# Altium schematic sheet 04 DragonBoard_INTF.SchDoc
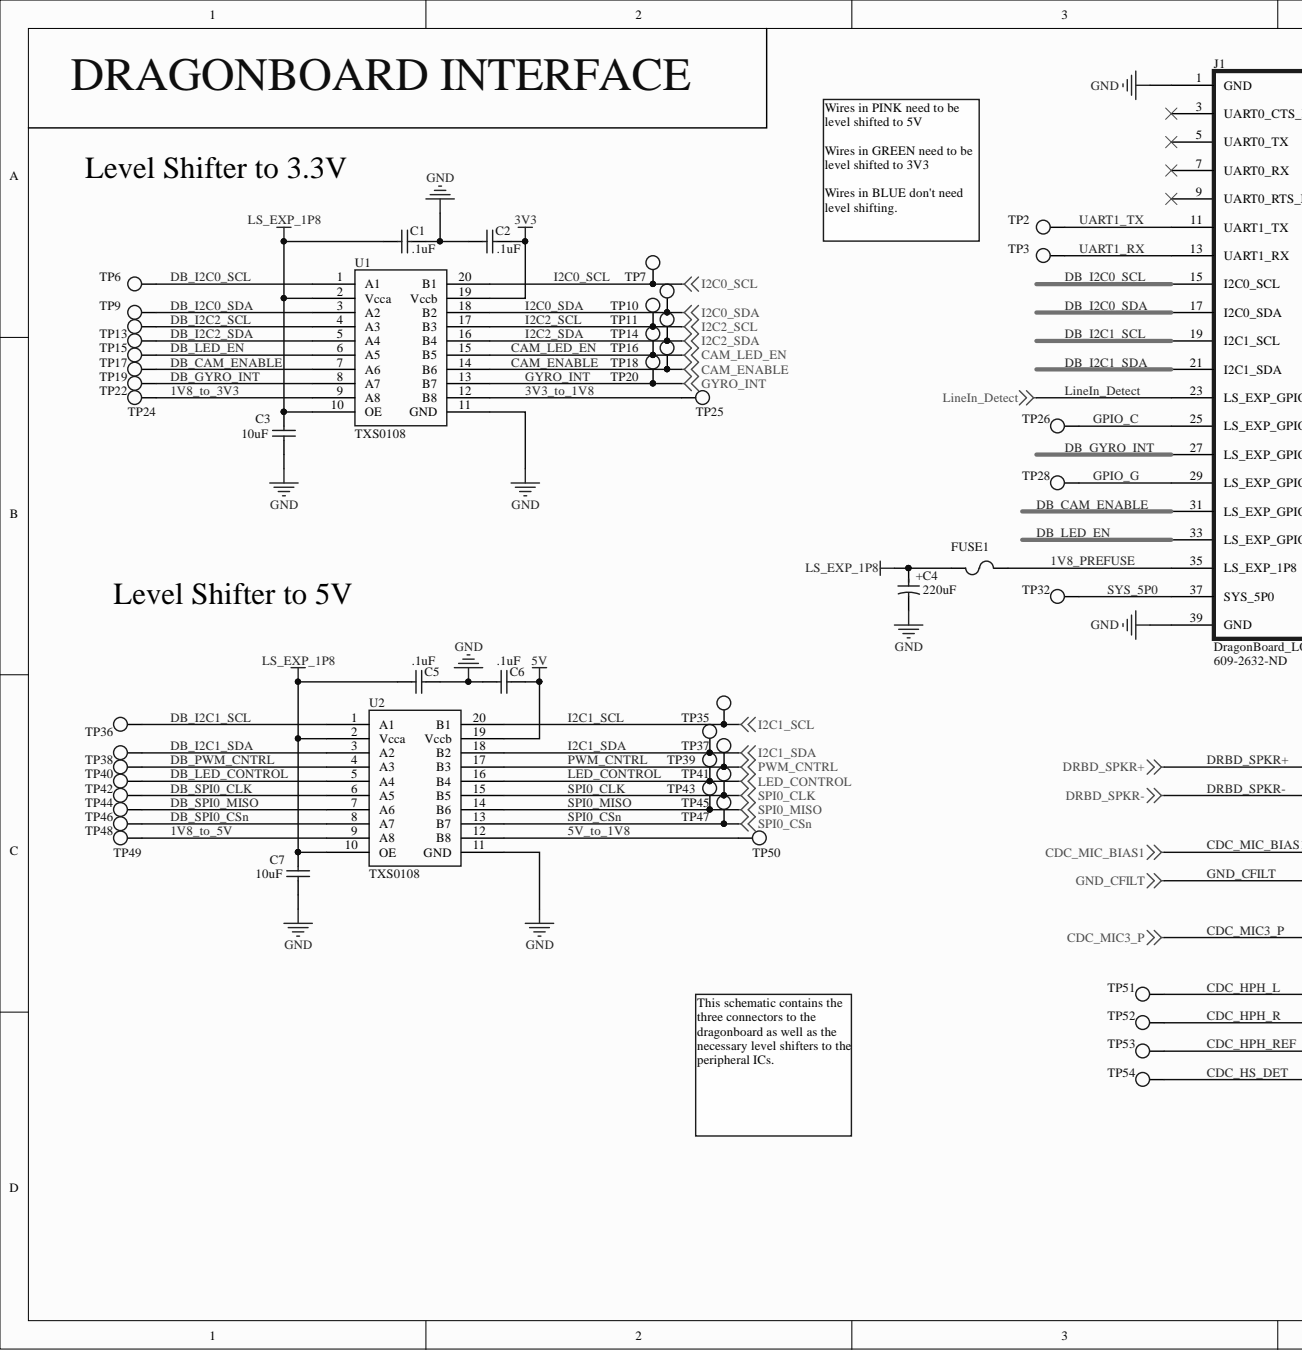
[diagram p0: 04 DragonBoard_INTF.SchDoc, left]
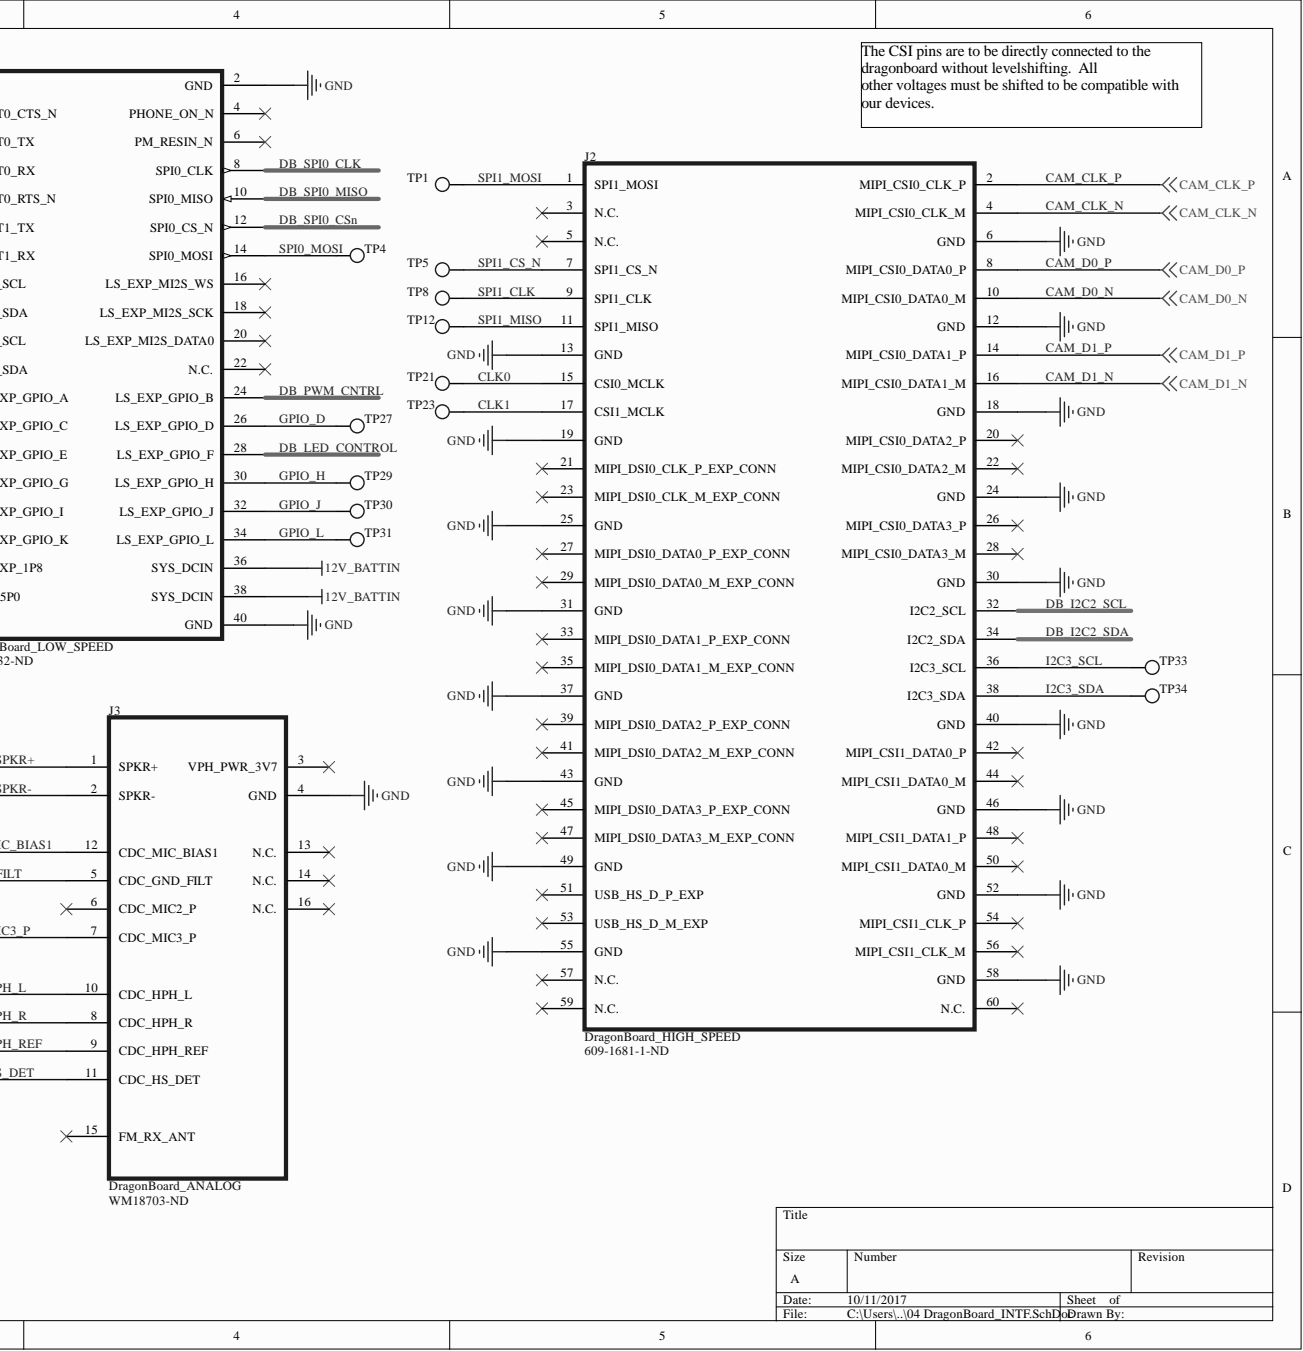
[diagram p1: 04 DragonBoard_INTF.SchDoc, right]

## Parts
C1  value .1uF  [CAPC2012X135N]  (hidden comment: CAP_0805_.1u_250V)
C2  value .1uF  [CAPC2012X135N]  (hidden comment: CAP_0805_.1u_250V)
C3  value 10uF  Capacitance: 10uF  [CAPC2012X135N]  (hidden comment: CAP_0805_10u_16V)
C4  Capacitance: 220uF  [CAP_RADIAL]  (hidden comment: CAP ALUM 220UF 20% 25V SMD)
C5  value .1uF  [CAPC2012X135N]  (hidden comment: CAP_0805_.1u_250V)
C6  value .1uF  [CAPC2012X135N]  (hidden comment: CAP_0805_.1u_250V)
C7  value 10uF  Capacitance: 10uF  [CAPC2012X135N]  (hidden comment: CAP_0805_10u_16V)
FUSE1  FUSE_0805_1A_32VDC  [RES0805]  (value not drawn)
J1  DragonBoard_LOW_SPEED  Supplier Part Number 1: 609-2632-ND  [57202_40_PIN_HEADER]
J2  DragonBoard_HIGH_SPEED  Supplier Part Number 1: 609-1681-1-ND  [DB_HIGH_SPEED]
J3  DragonBoard_ANALOG  Supplier Part Number 1: WM18703-ND  [16_PIN_ANALOG_CONN]
TP1  TP  [TestPoint_1206]
TP2  TP  [TestPoint_1206]
TP3  TP  [TestPoint_1206]
TP4  TP  [TestPoint_1206]
TP5  TP  [TestPoint_1206]
TP6  TP  [TestPoint_1206]
TP7  TP  [TestPoint_1206]
TP8  TP  [TestPoint_1206]
TP9  TP  [TestPoint_1206]
TP10  TP  [TestPoint_1206]
TP11  TP  [TestPoint_1206]
TP12  TP  [TestPoint_1206]
TP13  TP  [TestPoint_1206]
TP14  TP  [TestPoint_1206]
TP15  TP  [TestPoint_1206]
TP16  TP  [TestPoint_1206]
TP17  TP  [TestPoint_1206]
TP18  TP  [TestPoint_1206]
TP19  TP  [TestPoint_1206]
TP20  TP  [TestPoint_1206]
TP21  TP  [TestPoint_1206]
TP22  TP  [TestPoint_1206]
TP23  TP  [TestPoint_1206]
TP24  TP  [TestPoint_1206]
TP25  TP  [TestPoint_1206]
TP26  TP  [TestPoint_1206]
TP27  TP  [TestPoint_1206]
TP28  TP  [TestPoint_1206]
TP29  TP  [TestPoint_1206]
TP30  TP  [TestPoint_1206]
TP31  TP  [TestPoint_1206]
TP32  TP  [TestPoint_1206]
TP33  TP  [TestPoint_1206]
TP34  TP  [TestPoint_1206]
TP35  TP  [TestPoint_1206]
TP36  TP  [TestPoint_1206]
TP37  TP  [TestPoint_1206]
TP38  TP  [TestPoint_1206]
TP39  TP  [TestPoint_1206]
TP40  TP  [TestPoint_1206]
TP41  TP  [TestPoint_1206]
TP42  TP  [TestPoint_1206]
TP43  TP  [TestPoint_1206]
TP44  TP  [TestPoint_1206]
TP45  TP  [TestPoint_1206]
TP46  TP  [TestPoint_1206]
TP47  TP  [TestPoint_1206]
TP48  TP  [TestPoint_1206]
TP49  TP  [TestPoint_1206]
TP50  TP  [TestPoint_1206]
TP51  TP  [TestPoint_1206]
TP52  TP  [TestPoint_1206]
TP53  TP  [TestPoint_1206]
TP54  TP  [TestPoint_1206]
U1  TXS0108  [TSSOP20]
U2  TXS0108  [TSSOP20]

## Nets
3V3: C2.2 U1.19(Vccb)
5V: C6.2 U2.19(Vccb)
12V_BATTIN: J1.36(SYS_DCIN) J1.38(SYS_DCIN)
GND: C1.2 C2.1 C3.1 C4.2 C5.2 C6.1 C7.1 J1.1(GND) J1.2(GND) J1.39(GND) J1.40(GND) J2.6(GND) J2.12(GND) J2.13(GND) J2.18(GND) J2.19(GND) J2.24(GND) J2.25(GND) J2.30(GND) J2.31(GND) J2.37(GND) J2.40(GND) J2.43(GND) J2.46(GND) J2.49(GND) J2.52(GND) J2.55(GND) J2.58(GND) J3.4(GND) U1.11(GND) U2.11(GND)
LS_EXP_1P8: C1.1 C3.2 C4.1 C5.1 C7.2 FUSE1.1 U1.2(Vcca) U1.10(OE) U2.2(Vcca) U2.10(OE)
1V8_PREFUSE: FUSE1.2 J1.35(LS_EXP_1P8)
1V8_to_3V3: TP24.1 U1.9(A8)
1V8_to_5V: TP49.1 U2.9(A8)
3V3_to_1V8: TP25.1 U1.12(B8)
5V_to_1V8: TP50.1 U2.12(B8)
CAM_CLK_N: J2.4(MIPI_CSI0_CLK_M)
CAM_CLK_P: J2.2(MIPI_CSI0_CLK_P)
CAM_D0_N: J2.10(MIPI_CSI0_DATA0_M)
CAM_D0_P: J2.8(MIPI_CSI0_DATA0_P)
CAM_D1_N: J2.16(MIPI_CSI0_DATA1_M)
CAM_D1_P: J2.14(MIPI_CSI0_DATA1_P)
CAM_ENABLE: TP18.1 U1.14(B6)
CAM_LED_EN: TP16.1 U1.15(B5)
CDC_HPH_L: J3.10(CDC_HPH_L) TP51.1
CDC_HPH_R: J3.8(CDC_HPH_R) TP52.1
CDC_HPH_REF: J3.9(CDC_HPH_REF) TP53.1
CDC_HS_DET: J3.11(CDC_HS_DET) TP54.1
CDC_MIC3_P: J3.7(CDC_MIC3_P)
CDC_MIC_BIAS1: J3.12(CDC_MIC_BIAS1)
CLK0: J2.15(CSI0_MCLK) TP21.1
CLK1: J2.17(CSI1_MCLK) TP23.1
DB_CAM_ENABLE: J1.31(LS_EXP_GPIO_I) TP19.1 U1.7(A6)
DB_GYRO_INT: J1.27(LS_EXP_GPIO_E) TP22.1 U1.8(A7)
DB_I2C0_SCL: J1.15(I2C0_SCL) TP6.1 U1.1(A1)
DB_I2C0_SDA: J1.17(I2C0_SDA) TP9.1 U1.3(A2)
DB_I2C1_SCL: J1.19(I2C1_SCL) TP36.1 U2.1(A1)
DB_I2C1_SDA: J1.21(I2C1_SDA) TP38.1 U2.3(A2)
DB_I2C2_SCL: J2.32(I2C2_SCL) TP13.1 U1.4(A3)
DB_I2C2_SDA: J2.34(I2C2_SDA) TP15.1 U1.5(A4)
DB_LED_CONTROL: J1.28(LS_EXP_GPIO_F) TP42.1 U2.5(A4)
DB_LED_EN: J1.33(LS_EXP_GPIO_K) TP17.1 U1.6(A5)
DB_PWM_CNTRL: J1.24(LS_EXP_GPIO_B) TP40.1 U2.4(A3)
DB_SPI0_CLK: J1.8(SPI0_CLK) TP44.1 U2.6(A5)
DB_SPI0_CSn: J1.12(SPI0_CS_N) TP48.1 U2.8(A7)
DB_SPI0_MISO: J1.10(SPI0_MISO) TP46.1 U2.7(A6)
DRBD_SPKR+: J3.1(SPKR+)
DRBD_SPKR-: J3.2(SPKR-)
GND_CFILT: J3.5(CDC_GND_FILT)
GPIO_C: J1.25(LS_EXP_GPIO_C) TP26.1
GPIO_D: J1.26(LS_EXP_GPIO_D) TP27.1
GPIO_G: J1.29(LS_EXP_GPIO_G) TP28.1
GPIO_H: J1.30(LS_EXP_GPIO_H) TP29.1
GPIO_J: J1.32(LS_EXP_GPIO_J) TP30.1
GPIO_L: J1.34(LS_EXP_GPIO_L) TP31.1
GYRO_INT: TP20.1 U1.13(B7)
I2C0_SCL: TP7.1 U1.20(B1)
I2C0_SDA: TP10.1 U1.18(B2)
I2C1_SCL: TP35.1 U2.20(B1)
I2C1_SDA: TP37.1 U2.18(B2)
I2C2_SCL: TP11.1 U1.17(B3)
I2C2_SDA: TP14.1 U1.16(B4)
I2C3_SCL: J2.36(I2C3_SCL) TP33.1
I2C3_SDA: J2.38(I2C3_SDA) TP34.1
LED_CONTROL: TP41.1 U2.16(B4)
LineIn_Detect: J1.23(LS_EXP_GPIO_A)
PWM_CNTRL: TP39.1 U2.17(B3)
SPI0_CLK: TP43.1 U2.15(B5)
SPI0_CSn: TP47.1 U2.13(B7)
SPI0_MISO: TP45.1 U2.14(B6)
SPI0_MOSI: J1.14(SPI0_MOSI) TP4.1
SPI1_CLK: J2.9(SPI1_CLK) TP8.1
SPI1_CS_N: J2.7(SPI1_CS_N) TP5.1
SPI1_MISO: J2.11(SPI1_MISO) TP12.1
SPI1_MOSI: J2.1(SPI1_MOSI) TP1.1
SYS_5P0: J1.37(SYS_5P0) TP32.1
UART1_RX: J1.13(UART1_RX) TP3.1
UART1_TX: J1.11(UART1_TX) TP2.1

Not connected: J1 3(UART0_CTS_N) 4(PHONE_ON_N) 5(UART0_TX) 6(PM_RESIN_N) 7(UART0_RX) 9(UART0_RTS_N) 16(LS_EXP_MI2S_WS) 18(LS_EXP_MI2S_SCK) 20(LS_EXP_MI2S_DATA0) 22(N.C.); J2 3(N.C.) 5(N.C.) 20(MIPI_CSI0_DATA2_P) 21(MIPI_DSI0_CLK_P_EXP_CONN) 22(MIPI_CSI0_DATA2_M) 23(MIPI_DSI0_CLK_M_EXP_CONN) 26(MIPI_CSI0_DATA3_P) 27(MIPI_DSI0_DATA0_P_EXP_CONN) 28(MIPI_CSI0_DATA3_M) 29(MIPI_DSI0_DATA0_M_EXP_CONN) 33(MIPI_DSI0_DATA1_P_EXP_CONN) 35(MIPI_DSI0_DATA1_M_EXP_CONN) 39(MIPI_DSI0_DATA2_P_EXP_CONN) 41(MIPI_DSI0_DATA2_M_EXP_CONN) 42(MIPI_CSI1_DATA0_P) 44(MIPI_CSI1_DATA0_M) 45(MIPI_DSI0_DATA3_P_EXP_CONN) 47(MIPI_DSI0_DATA3_M_EXP_CONN) 48(MIPI_CSI1_DATA1_P) 50(MIPI_CSI1_DATA0_M) 51(USB_HS_D_P_EXP) 53(USB_HS_D_M_EXP) 54(MIPI_CSI1_CLK_P) 56(MIPI_CSI1_CLK_M) 57(N.C.) 59(N.C.) 60(N.C.); J3 3(VPH_PWR_3V7) 6(CDC_MIC2_P) 13(N.C.) 14(N.C.) 15(FM_RX_ANT) 16(N.C.)

## Library data of the parts
C1 C2 C5 C6 = CAP_0805_.1u_250V: Cap Ceramic 0.1uF 250V X7T 10% SMD 0805 125C Plastic T/R; Manufacturer: TDK; Manufacturer Part Number: C2012X7T2E104K125AE; Capacitance: 100nF; Case/Package: 0805; Case Code (Imperial): 0805; Case Code (Metric): 2012; Component Kind: Standard; ComponentLink1Description: https://datasheet.ciiva.com/24219/c2012x7t2e104k125ae-265154-24219519.pdf; ComponentLink1URL: https://datasheet.ciiva.com/24219/c2012x7t2e104k125ae-265154-24219519.pdf; Composition: Ceramic; Depth: 1.25mm; Dielectric: X7T; Height: 1.25mm; Length: 2mm; Max Operating Temperature: 125°C; Min Operating Temperature: -55°C; Package Quantity: 1; Packaging: Tape and Reel; Pricing 1: 1=0.37, 10=0.25, 100=0.1419, 500=0.1002, 1000=0.0877 (USD); REACH SVHC: No SVHC; Stock 1: 30376; Supplier 1: Digi-Key; Supplier Part Number 1: 445-8883-1-ND; Termination: SMD/SMT; Thickness: 0.049inch; Tolerance: 10%; Voltage Rating: 250V; Voltage Rating (DC): 250V; Weight: 0.000194oz; Width: 0.05inch; Library Name: schlib_truman.SchLib
C3 C7 = CAP_0805_10u_16V: Cap Ceramic 10uF 16V X5R 10% SMD 0805 85C T/R; Manufacturer: Johanson Dielectrics; Manufacturer Part Number: 160R15X106KV4E; Case/Package: 0805; Case Code (Imperial): 0805; Case Code (Metric): 2012; Component Kind: Standard; ComponentLink1Description: https://datasheet.ciiva.com/26948/getdatasheetpartid-132904-26948555.pdf; ComponentLink1URL: https://datasheet.ciiva.com/26948/getdatasheetpartid-132904-26948555.pdf; Depth: 1.27mm; Dielectric: X5R; Height: 1.27mm; Length: 2.03mm; Max Operating Temperature: 85°C; Min Operating Temperature: -55°C; Mount: Surface Mount; Number of Pins: 2; Package Quantity: 2000; Packaging: Tape and Reel; Pricing 1: 1=0.55, 10=0.387, 100=0.2552, 500=0.189, 1000=0.1607 (USD); Radiation Hardening: No; REACH SVHC: No SVHC; Stock 1: 36636; Supplier 1: Digi-Key; Supplier Part Number 1: 709-1229-1-ND; Termination: SMD/SMT; Thickness: 0.06inch; Tolerance: 10%; Voltage Rating: 16V; Voltage Rating (DC): 16V; Weight: 0.000194oz; Width: 1.27mm; Library Name: schlib_truman.SchLib
C4 = CAP_RAD_220u_25V: Manufacturer: Nichicon; Manufacturer Part Number: UWT1E221MNL1GS; Dielectric Material: Aluminium; Dissipation Factor: 0.16%; Leakage Current: 3uA; Life (Hours): 1000Hour; Ripple Current: 155mA; Case/Package: SMD/SMT; Component Kind: Standard; ComponentLink1Description: https://datasheet.ciiva.com/97/e-wt-97056.pdf; ComponentLink1URL: https://datasheet.ciiva.com/97/e-wt-97056.pdf; ComponentLink2Description: https://datasheet.ciiva.com/97/e-wt-97057.pdf; ComponentLink2URL: https://datasheet.ciiva.com/97/e-wt-97057.pdf; ComponentLink3Description: https://datasheet.ciiva.com/30522/getdatasheetpartid-450026-30522226.pdf; ComponentLink3URL: https://datasheet.ciiva.com/30522/getdatasheetpartid-450026-30522226.pdf; ComponentLink4Description: https://datasheet.ciiva.com/5360/e-wt-30307-5360729.pdf; ComponentLink4URL: https://datasheet.ciiva.com/5360/e-wt-30307-5360729.pdf; ComponentLink5Description: https://datasheet.ciiva.com/7851/e-wt-7851755.pdf; ComponentLink5URL: https://datasheet.ciiva.com/7851/e-wt-7851755.pdf; ComponentLink6Description: https://datasheet.ciiva.com/17526/e-wt-17526956.pdf; ComponentLink6URL: https://datasheet.ciiva.com/17526/e-wt-17526956.pdf; ComponentLink7Description: https://datasheet.ciiva.com/28458/1910938-28458412.pdf; ComponentLink7URL: https://datasheet.ciiva.com/28458/1910938-28458412.pdf; ComponentLink8Description: https://datasheet.ciiva.com/97/e-wt-97055.pdf; ComponentLink8URL: https://datasheet.ciiva.com/97/e-wt-97055.pdf; Description: CAP ALUM 220UF 20% 25V SMD; Diameter: 8mm; Height: 10mm; Height - Seated (Max): 0.394inch; Lead Free: Lead Free; Lead Pitch: 3.1mm; Length: 10mm; Max Operating Temperature: 105°C; Min Operating Temperature: -55°C; Mount: Surface Mount; Number of Pins: 2; Package Quantity: 500; Packaging: Tape and Reel; Pricing 1: 1=0.44, 10=0.309, 100=0.2034 (USD); Radiation Hardening: No; REACH SVHC: No SVHC; Ripple Current (AC): 155mA; Stock 1: 22315; Supplier 1: Digi-Key; Supplier Part Number 1: 493-2192-1-ND; Termination: SMD/SMT; Tolerance: 20%; Voltage: 25V; Voltage Rating: 25V; Voltage Rating (DC): 25V; Library Name: schlib_truman.SchLib
FUSE1 = FUSE_0805_1A_32VDC: FUSE 1.00A 0805 VFAST SMD; Manufacturer: Vishay; Manufacturer Part Number: MFU0805FF01000P100; Approvals: UL; Current Rating: 1A; DC Cold Resistance: 185mR; Voltage Rating (DC): 32V; Case/Package: 0805; Case Code (Imperial): 0805; Case Code (Metric): 2012; Component Kind: Standard; ComponentLink1Description: https://datasheet.ciiva.com/22662/1913674-22662919.pdf; ComponentLink1URL: https://datasheet.ciiva.com/22662/1913674-22662919.pdf; ComponentLink2Description: https://datasheet.ciiva.com/3018/mfuserie-3018078.pdf; ComponentLink2URL: https://datasheet.ciiva.com/3018/mfuserie-3018078.pdf; Depth: 1.25mm; Fuse Current: 1A; Height: 0.018inch; Housing Material: Ceramic; Lead Free: Lead Free; Length: 0.079inch; Max Operating Temperature: 125°C; Min Operating Temperature: -55°C; Mount: Surface Mount; Package Quantity: 1000; Packaging: Tape and Reel; Pricing 1: 1=0.49, 5=0.474, 10=0.459, 50=0.3866, 100=0.3209, 250=0.2917, 500=0.248 (USD); REACH SVHC: Unknown; Stock 1: 41804; Supplier 1: Digi-Key; Supplier Part Number 1: MFU08051.00CT-ND; Width: 0.049inch; Library Name: schlib_truman.SchLib
J1 = DragonBoard_LOW_SPEED: 40 Positions Header, Unshrouded Connector 0.079" (2.00mm) Surface Mount Gold, GXT™; Manufacturer: Amphenol FCI; Manufacturer Part Number: 57202-G52-20LF; Connector Type: Male Pin; Contact Resistance: 25mR; Current Rating: 2A; Gender: Male; Insulation Resistance: 1GR; Mating Post Length: 4mm; Max Voltage Rating (AC): 650V; Number of Contacts: 40; Pitch: 2mm; Row Spacing: 0.079inch; Colour: Black; Component Kind: Standard; ComponentLink1Description: https://datasheet.ciiva.com/9473/57202-9473862.pdf; ComponentLink1URL: https://datasheet.ciiva.com/9473/57202-9473862.pdf; ComponentLink2Description: https://datasheet.ciiva.com/711/57202-711726.pdf; ComponentLink2URL: https://datasheet.ciiva.com/711/57202-711726.pdf; Contact Gender: Male; Contact Material: Bronze,Copper Alloy; Contact Mating Length: 0.157inch; Contact Plating: Nickel,Gold,GXT; Depth: 4mm; Housing Colour: Black; Housing Material: Thermoplastic; Lead Free: Lead Free; Length: 40mm; Max Operating Temperature: 125°C; Min Operating Temperature: -55°C; Mount: Surface Mount; Number of Positions: 40; Number of Rows: 2; Orientation: Straight; Package Quantity: 100; Packaging: Bulk; Pricing 1: 1=4.58, 10=4.395, 100=3.6624, 500=3.113, 1000=2.6552 (USD); Radiation Hardening: No; REACH SVHC: No SVHC; Stock 1: 3189; Supplier 1: Digi-Key; Termination: Solder; Voltage Rating: 650V; Width: 4mm; Library Name: schlib_truman.SchLib
J2 = DragonBoard_HIGH_SPEED: 60 Position Connector Plug, Center Strip Contacts Surface Mount Gold; Manufacturer: Amphenol FCI; Manufacturer Part Number: 61083-064402LF; Contact Resistance: 50mR; Current Rating: 500mA; Gender: Male; Max Voltage Rating (AC): 100V; Number of Contacts: 60; Pitch: 0.8mm; Stack Height: 7.7mm; Case/Package: SMD/SMT; Component Kind: Standard; ComponentLink1Description: https://datasheet.ciiva.com/15208/getdatasheetpartid-64898-15208309.pdf; ComponentLink1URL: https://datasheet.ciiva.com/15208/getdatasheetpartid-64898-15208309.pdf; ComponentLink2Description: https://datasheet.ciiva.com/17088/70248518-17088294.pdf; ComponentLink2URL: https://datasheet.ciiva.com/17088/70248518-17088294.pdf; ComponentLink3Description: https://datasheet.ciiva.com/394/61083-394311.pdf; ComponentLink3URL: https://datasheet.ciiva.com/394/61083-394311.pdf; ComponentLink4Description: https://datasheet.ciiva.com/5129/61083-5129495.pdf; ComponentLink4URL: https://datasheet.ciiva.com/5129/61083-5129495.pdf; ComponentLink5Description: https://datasheet.ciiva.com/10034/61083-10034439.pdf; ComponentLink5URL: https://datasheet.ciiva.com/10034/61083-10034439.pdf; ComponentLink6Description: https://datasheet.ciiva.com/394/61083-394312.pdf; ComponentLink6URL: https://datasheet.ciiva.com/394/61083-394312.pdf; ComponentLink7Description: https://datasheet.ciiva.com/394/61083-394313.pdf; ComponentLink7URL: https://datasheet.ciiva.com/394/61083-394313.pdf; Connector Type: Plug; Contact Material: Brass,Copper Alloy; Contact Plating: Nickel,Gold; Depth: 6mm; Flammability Rating: UL94 V-0; Housing Material: Polymer; Lead Free: Lead Free; Length: 29.8mm; Max Operating Temperature: 125°C; Min Operating Temperature: -40°C; Mount: Surface Mount; Number of Positions: 60; Number of Rows: 2; Orientation: Straight; Package Quantity: 600; Packaging: Tape and Reel; Pricing 1: 1=6.36, 10=6.094, 25=5.5644, 50=5.2994, 100=5.0344, 250=4.5044 (USD); Stock 1: 579; Supplier 1: Digi-Key; Termination: Solder; Voltage Rating: 100V; Width: 6mm; Library Name: schlib_truman.SchLib
J3 = DragonBoard_ANALOG: Conn Socket Strip RCP 16 POS 2mm Solder ST SMD Tube; Manufacturer: Molex; Manufacturer Part Number: 0791091007; Connector Type: Receptacle,Socket; Contact Resistance: 25mR; Gender: Female; Max Voltage Rating (AC): 125V; Number of Contacts: 16; Row Spacing: 0.079inch; Case/Package: SMD/SMT; Colour: Black; Component Kind: Standard; ComponentLink1Description: https://datasheet.ciiva.com/10078/0791091007-pcb-receptacles-10078749.pdf; ComponentLink1URL: https://datasheet.ciiva.com/10078/0791091007-pcb-receptacles-10078749.pdf; Contact Material: Bronze; Contact Plating: Nickel,Gold; Depth: 4.19mm; Housing Colour: Black; Housing Material: Polymer; Length: 16.19mm; Max Operating Temperature: 105°C; Min Operating Temperature: -55°C; Number of Positions: 16; Number of Rows: 2; Orientation: Straight; Packaging: Rail/Tube; Pitch: 2mm; Pricing 1: 1=2.66, 10=2.554, 100=2.1284, 500=1.8091, 1000=1.5431, 5000=1.3835 (USD); Stock 1: 8937; Supplier 1: Digi-Key; Termination: Solder; Library Name: schlib_truman.SchLib
TP1-TP54 = TP: Testpoint_1206; Manufacturer: TE Connectivity; Manufacturer Part Number: 1625854-4; Resistance: 0mR; Case/Package: 1206; Colour: Natural; Component Kind: Standard; ComponentLink1Description: https://datasheet.ciiva.com/16499/1625854-4-16499923.pdf; ComponentLink1URL: https://datasheet.ciiva.com/16499/1625854-4-16499923.pdf; ComponentLink2Description: https://datasheet.ciiva.com/6439/eng-ds-1773266-a-6439173.pdf; ComponentLink2URL: https://datasheet.ciiva.com/6439/eng-ds-1773266-a-6439173.pdf; ComponentLink3Description: https://datasheet.ciiva.com/6439/eng-ds-1773266-a-6439161.pdf; ComponentLink3URL: https://datasheet.ciiva.com/6439/eng-ds-1773266-a-6439161.pdf; ComponentLink4Description: https://datasheet.ciiva.com/36535/eng-ds-1773266-a-36535790.pdf; ComponentLink4URL: https://datasheet.ciiva.com/36535/eng-ds-1773266-a-36535790.pdf; Depth: 1.6mm; Lead Free: Lead Free; Length: 3.2mm; Max Operating Temperature: 125°C; Military Standard: Not; Min Operating Temperature: -55°C; Package Quantity: 2000; Packaging: Tape and Reel; Pricing 1: 1=0.28, 10=0.261, 25=0.224, 50=0.1902, 100=0.1828, 250=0.1641, 500=0.1567, 1000=0.1306 (USD); Radiation Hardening: No; Stock 1: 23359; Supplier 1: Digi-Key; Supplier Part Number 1: A106146CT-ND; Library Name: schlib_truman.SchLib
U1 U2 = TXS0108: TRANSLATOR, VOLTAGE, 8BIT, 20TSSOP; Manufacturer: Texas Instruments; Manufacturer Part Number: TXS0108EPWR; Logic Case Style: TSSOP; Logic Type: Voltage Level Translator; No. of Inputs: 8; No. of Pins: 20; Output Current: 620µA; Propagation Delay: 4.8ns; Supply Voltage Max: 5.5V; Supply Voltage Min: 1.2V; SVHC: No SVHC (16-Jun-2014); Component Kind: Standard; ComponentLink1Description: https://datasheet.ciiva.com/14287/txs0108e-14287280.pdf; ComponentLink1URL: https://datasheet.ciiva.com/14287/txs0108e-14287280.pdf; ComponentLink2Description: https://datasheet.ciiva.com/4834/sces642b-127094-4834401.pdf; ComponentLink2URL: https://datasheet.ciiva.com/4834/sces642b-127094-4834401.pdf; ComponentLink3Description: https://datasheet.ciiva.com/30509/txs0108epwr-30509699.pdf; ComponentLink3URL: https://datasheet.ciiva.com/30509/txs0108epwr-30509699.pdf; ComponentLink4Description: https://datasheet.ciiva.com/1899/txs0108e-1899604.pdf; ComponentLink4URL: https://datasheet.ciiva.com/1899/txs0108e-1899604.pdf; ComponentLink5Description: https://datasheet.ciiva.com/15096/getdatasheetpartid-322119-15096276.pdf; ComponentLink5URL: https://datasheet.ciiva.com/15096/getdatasheetpartid-322119-15096276.pdf; ComponentLink6Description: https://datasheet.ciiva.com/1899/txs0108e-1899605.pdf; ComponentLink6URL: https://datasheet.ciiva.com/1899/txs0108e-1899605.pdf; ComponentLink7Description: https://datasheet.ciiva.com/28653/1714661-28653429.pdf; ComponentLink7URL: https://datasheet.ciiva.com/28653/1714661-28653429.pdf; ComponentLink8Description: https://datasheet.ciiva.com/1899/txs0108e-1899606.pdf; ComponentLink8URL: https://datasheet.ciiva.com/1899/txs0108e-1899606.pdf; ComponentLink9Description: https://datasheet.ciiva.com/1508/1815244-1508732.pdf; ComponentLink9URL: https://datasheet.ciiva.com/1508/1815244-1508732.pdf; ComponentLink10Description: https://datasheet.ciiva.com/14287/txs0108e-14287532.pdf; ComponentLink10URL: https://datasheet.ciiva.com/14287/txs0108e-14287532.pdf; ComponentLink11Description: https://datasheet.ciiva.com/26039/txs0108e-26039599.pdf; ComponentLink11URL: https://datasheet.ciiva.com/26039/txs0108e-26039599.pdf; MSL: MSL 1 - Unlimited; Operating Temperature Max: 85°C; Operating Temperature Min: -40°C; Packaging: Cut Tape; Pricing 1: 1=1.7, 10=1.523, 100=1.2242, 500=1.0058, 1000=0.8334 (USD); Stock 1: 41812; Supplier 1: Digi-Key; Supplier Part Number 1: 296-23011-1-ND; Library Name: schlib_truman.SchLib
